# Altium schematic sheet Conversor_DC_DC_5V.SchDoc
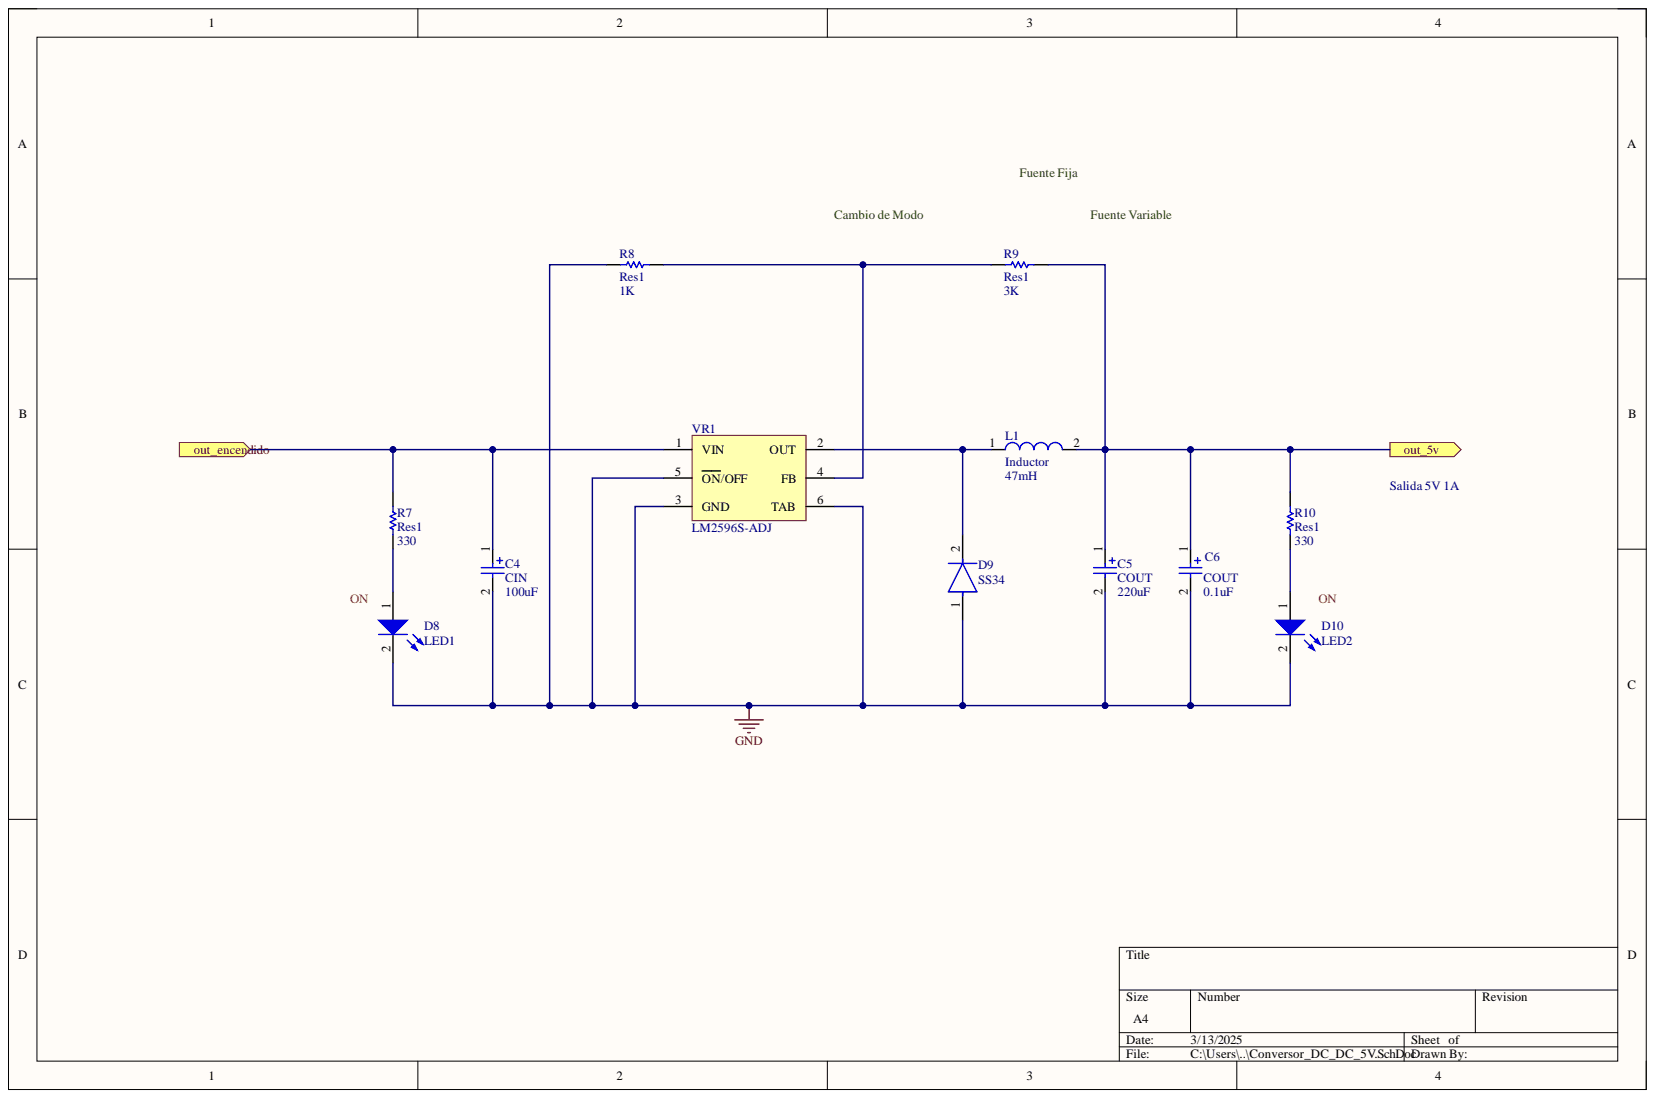
[diagram p0: Conversor_DC_DC_5V.SchDoc]

## Parts
C4  CIN  value 100uF  [CAPACITOR ALUMINUM]
C5  COUT  value 220uF  [CAPACITOR ALUMINUM]
C6  COUT  value 0.1uF  [CC1206]
D8  LED1  [LED 0805]
D9  SS34  [SS34 DIODE]
D10  LED2  [LED 0805]
L1  Inductor  value 47mH  [INDUCTOR]
R7  Res1  value 330  [RESISTOR 1206]
R8  Res1  value 1K  [RESISTOR 1206]
R9  Res1  value 3K  [RESISTOR 1206]
R10  Res1  value 330  [RESISTOR 1206]
VR1  LM2596S-ADJ  [lm2596S]

## Ports
out_5v, out_encendido

## Nets
GND: C4.2 C5.2 C6.2 D8.2 D9.1 D10.2 R8.1 VR1.3(GND) VR1.5(ON/OFF) VR1.6(TAB)
out_5v: C5.1 C6.1 L1.2 R9.2 R10.2 ; port out_5v
out_encendido: C4.1 R7.2 VR1.1(VIN) ; port out_encendido
NetD8_1: D8.1 R7.1
NetD9_2: D9.2 L1.1 VR1.2(OUT)
NetD10_1: D10.1 R10.1
NetR8_2: R8.2 R9.1 VR1.4(FB)

## Library data of the parts
C4 = Cap Pol1: Polarized Capacitor (Radial); Component Kind: Standard; LatestRevisionDate: 17-Jul-2002; LatestRevisionNote: Re-released for DXP Platform.; PackageDescription: Polarized Capacitor (Radial); 2 Leads; PackageReference: RB7.6-15; Published: 8-Jun-2000; Signal Integrity: Cap Pol; Simulation: CAP; Library Name: PCB_Proyecto_Fuente_Voltaje.SCHLIB; Publisher: Altium Limited
C5 = Cap Pol1_1: Polarized Capacitor (Radial); Component Kind: Standard; LatestRevisionDate: 17-Jul-2002; LatestRevisionNote: Re-released for DXP Platform.; PackageDescription: Polarized Capacitor (Radial); 2 Leads; PackageReference: RB7.6-15; Published: 8-Jun-2000; Signal Integrity: Cap Pol; Simulation: CAP; Library Name: PCB_Proyecto_Fuente_Voltaje.SCHLIB; Publisher: Altium Limited
C6 = Cap Pol1_2: Polarized Capacitor (Radial); Component Kind: Standard; LatestRevisionDate: 17-Jul-2002; LatestRevisionNote: Re-released for DXP Platform.; PackageDescription: Polarized Capacitor (Radial); 2 Leads; PackageReference: RB7.6-15; Published: 8-Jun-2000; Signal Integrity: Cap Pol; Simulation: CAP; Library Name: PCB_Proyecto_Fuente_Voltaje.SCHLIB; Publisher: Altium Limited
D8 = LED0_1: Typical INFRARED GaAs LED; Component Kind: Standard; LatestRevisionDate: 08-Jul-2005; LatestRevisionNote: Mech Layer 1 removed.; PackageDescription: LED; 2 Leads; PackageReference: LED-0; Published: 8-Jun-2000; Simulation: LED0; Library Name: PCB_Proyecto_Fuente_Voltaje.SCHLIB; Publisher: Altium Limited
D9 = CMP-02539-000012-1: DIODE SCHOTTKY 40V 3A DO214AB; Manufacturer: Vishay; Manufacturer Part Number: SS34-E3/57T; Max Junction Temp: +150°C; Max Surge Forward Current: 100A; Peak Reverse Current: 0.5mA; Standoff Height: 0mm; Automotive: No; Category: Diode; Component Kind: Standard; ComponentLink2Description: Datasheet; ComponentLink2URL: http://www.vishay.com/docs/88751/ss32.pdf; Device Class L1: Discrete Semiconductors; Device Class L2: Diodes; Device Class L3: Schottky Diodes; Digikey Description: DIODE SCHOTTKY 40V 3A DO214AB; Footprint Url: http://www.vishay.com/docs/95402/smc.pdf; Forward Current: 3A; Height: 2.62mm; Lead Free: Yes; Max Repetitive Reverse Voltage: 40V; Mouser Description: Schottky Diodes & Rectifiers 3.0 Amp 40 Volt; Octopart: https://octopart.com/ss34-e3%2F57t-vishay-39552907; Package: 95402; RoHS: TRUE; Temperature: -55°C to +150°C; Temperature Range High: +150°C; Temperature Range Low: -55°C; Library Name: biblioteca_esquematica.SchLib
D10 = LED0: Typical INFRARED GaAs LED; Component Kind: Standard; LatestRevisionDate: 08-Jul-2005; LatestRevisionNote: Mech Layer 1 removed.; PackageDescription: LED; 2 Leads; PackageReference: LED-0; Published: 8-Jun-2000; Simulation: LED0; Library Name: PCB_Proyecto_Fuente_Voltaje.SCHLIB; Publisher: Altium Limited
L1 = Inductor: Code_IEC: 1005; Code_JEITA: 0402; Component Kind: Standard; Description: Inductor; LatestRevisionDate: 29-May-2009; LatestRevisionNote: IPC-7351 Footprint Added.; PackageDescription: Chip Inductor; PackageReference: 0402-A; Published: 8-Jun-2000; Signal Integrity: Inductor; Simulation: INDUCTOR; Library Name: PCB_Proyecto_Fuente_Voltaje.SCHLIB; Publisher: Altium Limited
R7 R10 = Res1_2: Resistor; Component Kind: Standard; LatestRevisionDate: 17-Jul-2002; LatestRevisionNote: Re-released for DXP Platform.; PackageDescription: Axial Device, Thru-Hole; 2 Leads; 0.3 in Pin Spacing; PackageReference: AXIAL-0.3; Published: 8-Jun-2000; Signal Integrity: Res; Simulation: RESISTOR; Library Name: PCB_Proyecto_Fuente_Voltaje.SCHLIB; Publisher: Altium Limited
R8 = Res1: Resistor; Component Kind: Standard; LatestRevisionDate: 17-Jul-2002; LatestRevisionNote: Re-released for DXP Platform.; PackageDescription: Axial Device, Thru-Hole; 2 Leads; 0.3 in Pin Spacing; PackageReference: AXIAL-0.3; Published: 8-Jun-2000; Signal Integrity: Res; Simulation: RESISTOR; Library Name: PCB_Proyecto_Fuente_Voltaje.SCHLIB; Publisher: Altium Limited
R9 = Res1_1: Resistor; Component Kind: Standard; LatestRevisionDate: 17-Jul-2002; LatestRevisionNote: Re-released for DXP Platform.; PackageDescription: Axial Device, Thru-Hole; 2 Leads; 0.3 in Pin Spacing; PackageReference: AXIAL-0.3; Published: 8-Jun-2000; Signal Integrity: Res; Simulation: RESISTOR; Library Name: PCB_Proyecto_Fuente_Voltaje.SCHLIB; Publisher: Altium Limited
VR1 = LM2596S-ADJ: SIMPLE SWITCHER® Power Converter 150 KHz 3A Step-Down Voltage Regulator, 5-pin TO-263; Manufacturer: National Semiconductor; Manufacturer Part Number: LM2596SADJ; PackageTopMarking: NSUZXYTTE#*LM2596S*-ADJ P+; PowerWise: N; Code_JEDEC: MO-169-AB; Component Kind: Standard; ComponentLink1Description: LM2596 Datasheet; ComponentLink1URL: http://www.national.com/ds/LM/LM2596.pdf; ComponentLink2Description: LM2596 Web Page; ComponentLink2URL: http://www.national.com/pf/LM/LM2596.html; ComponentLink3Description: TS5B Package Page; ComponentLink3URL: http://www.national.com/packaging/folders/ts5b.html; CompType: Switcher; DatasheetVersion: May-2002; PackageDescription: TO-263, Molded, .400 x .390 x .180in, 5 Lead, .067in Pitch, Surface Mount; PackageReference: TS5B; PackageVersion: revD, Apr-2005; PinCount: 5; RevisionNote: Component URLs added; RoHS: N; Library Name: PCB_Proyecto_Fuente_Voltaje.SCHLIB
